# Altium schematic sheet Arak-DAC.SchDoc
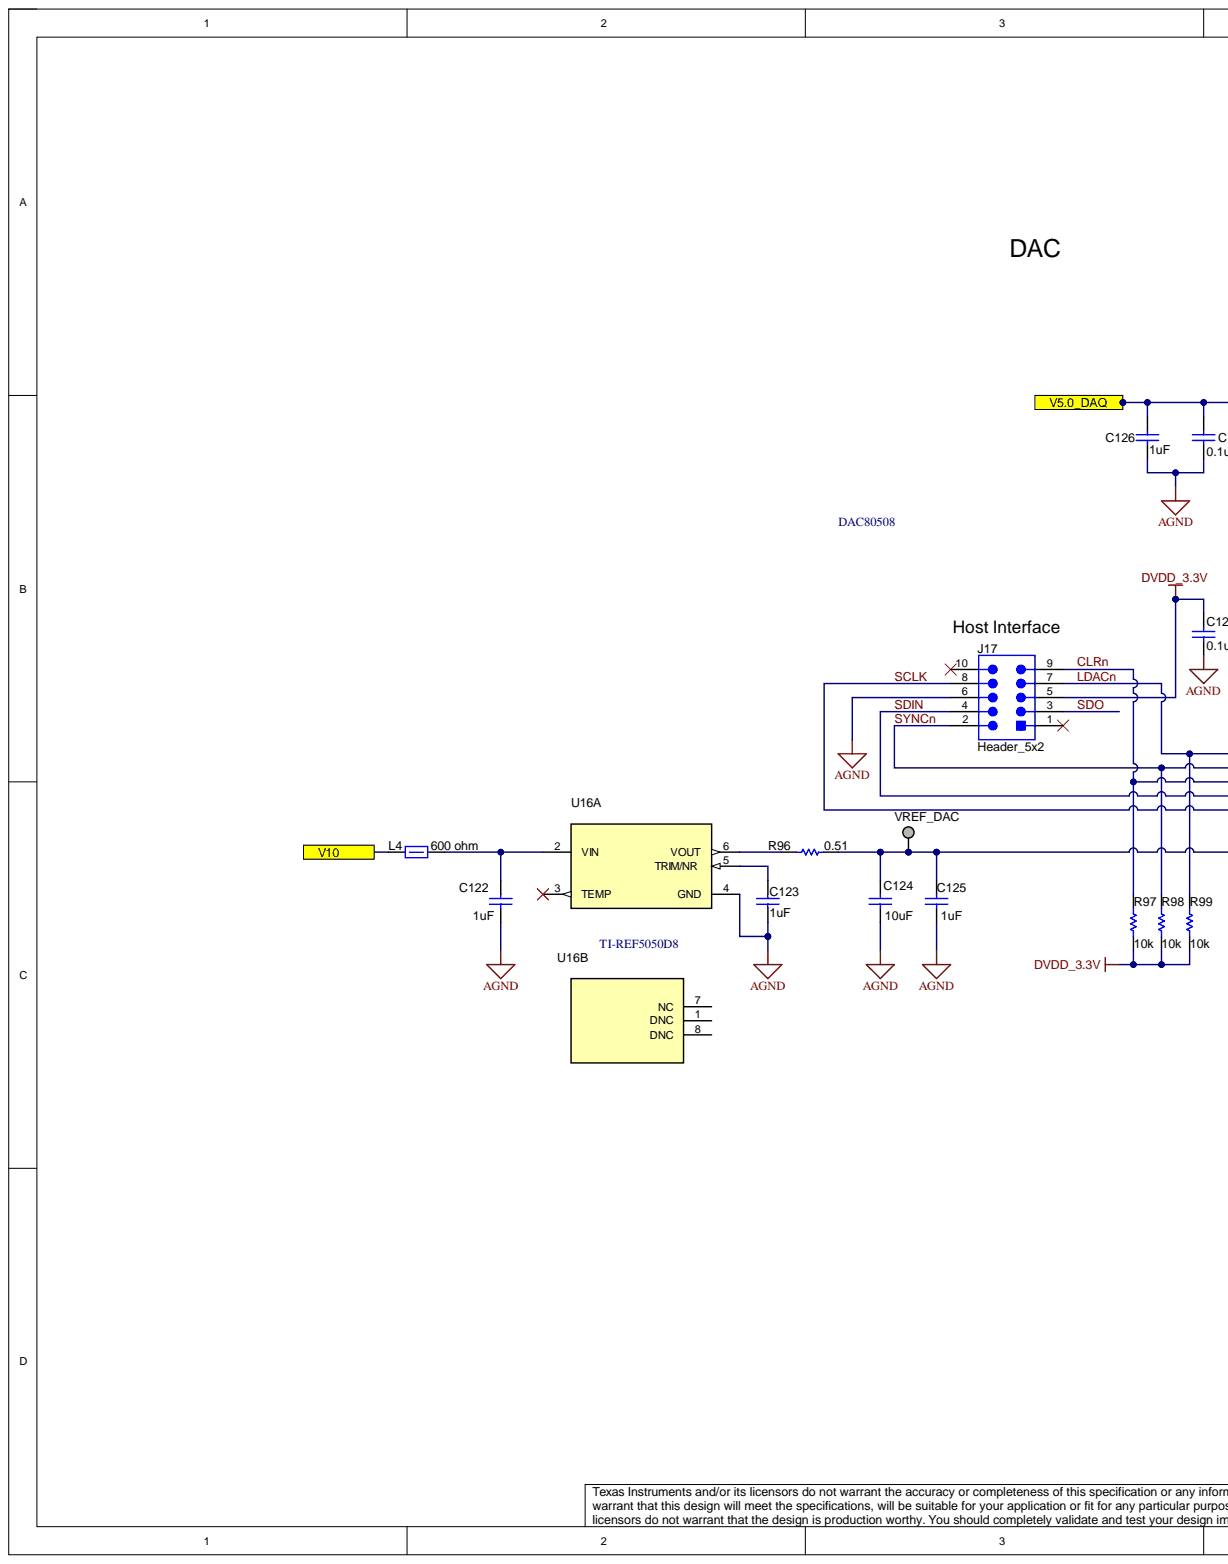
[diagram p0: Arak-DAC.SchDoc, left]
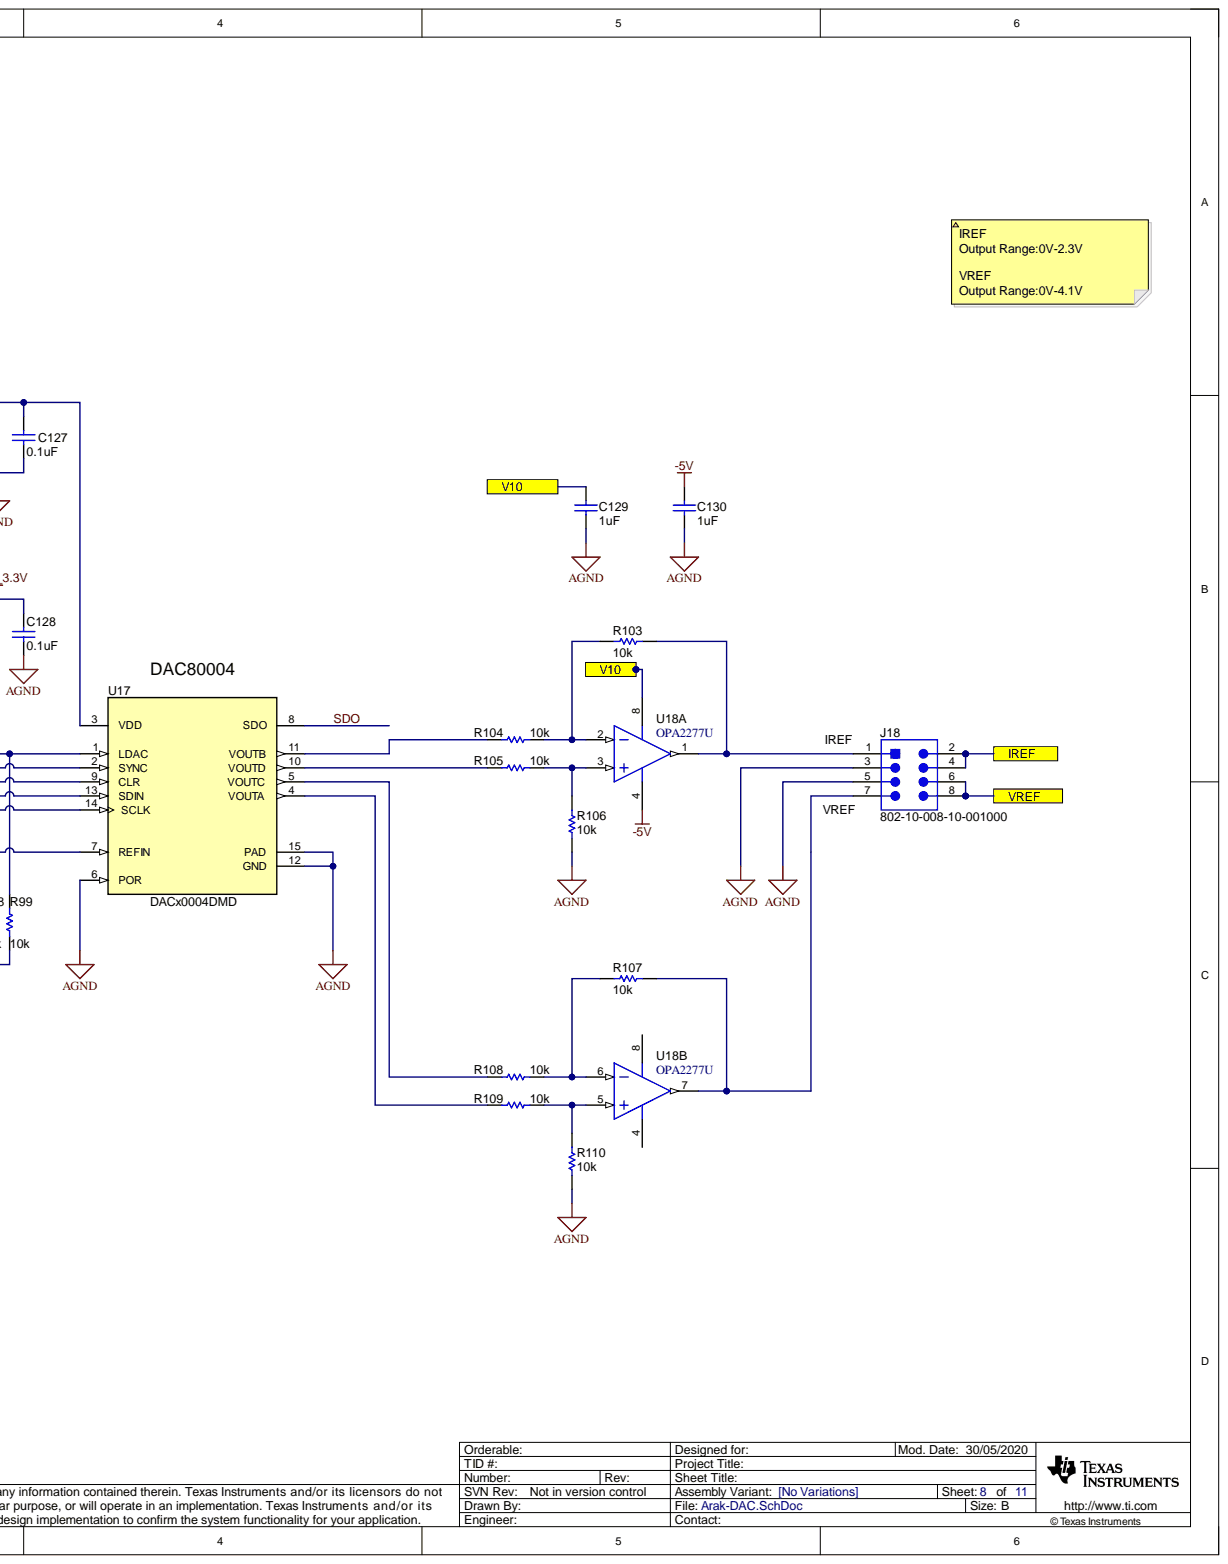
[diagram p1: Arak-DAC.SchDoc, right]

## Parts
C122  value 1uF  [1206]  (hidden comment: Capacitor)
C123  value 1uF  [1206]  (hidden comment: Capacitor)
C124  value 10uF  [0805_HV]  (hidden comment: Capacitor)
C125  value 1uF  [1206]  (hidden comment: Capacitor)
C126  value 1uF  [1206]  (hidden comment: Capacitor)
C127  value 0.1uF  [0603]  (hidden comment: Capacitor)
C128  value 0.1uF  [0603]  (hidden comment: Capacitor)
C129  value 1uF  [0603]  (hidden comment: Capacitor)
C130  value 1uF  [0603]  (hidden comment: Capacitor)
J17  Header_5x2  [Assman_AWHW-10G-0x02-T]
J18  802-10-008-10-001000  [Mill-Max_802-10-008-10-001000]
L4  value 600 ohm  [0603]  (hidden comment: Ferrite_Bead)
R96  value 0.51  [0603]  (hidden comment: Resistor)
R97  value 10k  Res_ohm: 10k  [0603]  (hidden comment: Resistor)
R98  value 10k  Res_ohm: 10k  [0603]  (hidden comment: Resistor)
R99  value 10k  Res_ohm: 10k  [0603]  (hidden comment: Resistor)
R103  value 10k  Res_ohm: 10k  [0603]  (hidden comment: Resistor)
R104  value 10k  Res_ohm: 10k  [0603]  (hidden comment: Resistor)
R105  value 10k  Res_ohm: 10k  [0603]  (hidden comment: Resistor)
R106  value 10k  Res_ohm: 10k  [0603]  (hidden comment: Resistor)
R107  value 10k  Res_ohm: 10k  [0603]  (hidden comment: Resistor)
R108  value 10k  Res_ohm: 10k  [0603]  (hidden comment: Resistor)
R109  value 10k  Res_ohm: 10k  [0603]  (hidden comment: Resistor)
R110  value 10k  Res_ohm: 10k  [0603]  (hidden comment: Resistor)
U16  TI-REF5050D8  [D0008A_N]  (parts A,B)
U17  DACx0004DMD  [DMD0014A]
U18  OPA2277U  [D0008A_N]  (parts A,B)
VREF_DAC  Pogo Pad  [PogoPad]  (value not drawn)  (no BOM)

## Ports
IREF, V5.0_DAQ, V10, VREF

## Nets
-5V: C130.1 U18.4
AGND: C122.2 C123.2 C124.2 C125.2 C126.2 C127.2 C128.2 C129.2 C130.2 J17.6 J18.3 J18.5 R106.1 R110.1 U16.4(GND) U17.6(POR) U17.12(GND) U17.15(PAD)
DVDD_3.3V: C128.1 J17.5 R97.1 R98.1 R99.1
CLRn: J17.9 R97.2 U17.9(CLR)
IREF: J18.2 J18.4 ; port IREF
LDACn: J17.7 R99.2 U17.1(LDAC)
SCLK: J17.8 U17.14(SCLK)
SDIN: J17.4 U17.13(SDIN)
SDO: J17.3 U17.8(SDO)
SYNCn: J17.2 R98.2 U17.2(SYNC)
V5.0_DAQ: C126.1 C127.1 U17.3(VDD) ; port V5.0_DAQ
V10: C129.1 L4.1 U18.8 ; port V10
VREF: J18.6 J18.8 ; port VREF
NetC122_1: C122.1 L4.2 U16.2(VIN)
NetC123_1: C123.1 U16.5(TRIM/NR)
NetC124_1: C124.1 C125.1 R96.2 U17.7(REFIN) VREF_DAC.1
NetJ18_1: J18.1 R103.1 U18.1
NetJ18_7: J18.7 R107.1 U18.7
NetR96_1: R96.1 U16.6(VOUT)
NetR103_2: R103.2 R104.1 U18.2
NetR104_2: R104.2 U17.11(VOUTB)
NetR105_1: R105.1 R106.2 U18.3
NetR105_2: R105.2 U17.10(VOUTD)
NetR107_2: R107.2 R108.1 U18.6
NetR108_2: R108.2 U17.5(VOUTC)
NetR109_1: R109.1 R110.2 U18.5
NetR109_2: R109.2 U17.4(VOUTA)

Not connected: J17 1 10; U16 1(DNC) 3(TEMP) 7(NC) 8(DNC)

## Library data of the parts
C122 C123 C125 C126 = CMP-0008509-2: CAP, CERM, 1 uF, 25 V, +/- 10%, X7R, 1206; Manufacturer: AVX; PartNumber: 12063C105KAT2A; AEC Category: Q200; AEC Qualified: N; Cap_F: 1µF; ESR_ohm: 0.013; SizeArea_mm2: 5.12; SizeAreaWB_mm2: 10.92; SizeX_mm: 3.2; SizeY_mm: 1.6; SizeZ_mm: 1.35; TaMax_C: 125°C; TaMin_C: -55°C; U/M: EA; Value1: 0.013 ohm; Value2: 25V; AEC Grade: N/A; Cap_Case: 1206; Component Kind: Standard; ComponentLink1Description: Datasheet link; ComponentLink1URL: http://datasheets.avx.com/X7RDielectric.pdf; CompType: Capacitor; LatestRevisionDate: 2017-03-09; Library Name: Altium Vault - TI; MountType: SMT; PackageReference: 1206; Polarized: N; Published: 2008-06-19; RoHS: Y; Supplier 1: Digi-Key; Supplier 2: Newark; Supplier 3: Mouser; Supplier Part Number 1: 478-1567-1-ND; Supplier Part Number 2: 96K4787; Supplier Part Number 3: 581-12063C105K; Technology: Ceramic; TempCo: X7R; Tolerance_%: 10%; ValueDisplayed: 1uF; VDC_V: 25V; Publisher: Texas Instruments
C124 = CMP-0008273-1: CAP, CERM, 10 uF, 35 V, +/- 10%, X6S, 0805; Manufacturer: MuRata; PartNumber: GRM21BC8YA106KE11L; AEC Category: Q200; AEC Qualified: N; Cap_Case: 0805; Cap_F: 10µF; SizeArea_mm2: 2.5; SizeAreaWB_mm2: 6.75; SizeX_mm: 2; SizeY_mm: 1.25; SizeZ_mm: 1.45; TaMax_C: 105°C; TaMin_C: -55°C; U/M: EA; Value1: Unknown; Value2: 35V; AEC Grade: N/A; Component Kind: Standard; ComponentLink1Description: Datasheet link; ComponentLink1URL: http://search.murata.co.jp/Ceramy/image/img/A01X/G101/ENG/GRM21BC8YA106KE11-01.pdf; CompType: Capacitor; LatestRevisionDate: 2017-03-09; Library Name: Altium Vault - TI; MountType: SMT; PackageReference: 0805; Polarized: N; Published: 2008-06-19; RoHS: Y; Supplier 1: Digi-Key; Supplier 2: Newark; Supplier 3: Mouser; Supplier Part Number 1: 490-10504-1-ND; Supplier Part Number 2: 96Y9269; Supplier Part Number 3: 81-GRM21BC8YA106KE1L; Technology: Ceramic; TempCo: X6S; Tolerance_%: 10%; ValueDisplayed: 10uF; VDC_V: 35V; Publisher: Texas Instruments
C127 C128 = CMP-0006857-4: CAP, CERM, 0.1 uF, 16 V, +/- 5%, X7R, 0603; Manufacturer: Kemet; PartNumber: C0603C104J4RACTU; AEC Category: Q200; Cap_F: 100nF; Polarized: N; SizeArea_mm2: 1.28; SizeAreaWB_mm2: 4.68; SizeX_mm: 1.6; SizeY_mm: 0.8; SizeZ_mm: 1; TaMax_C: 125°C; TaMin_C: -55°C; U/M: EA; Value1: Unknown; Value2: 16V; AEC Qualified: ?; Cap_Case: 0603; Component Kind: Standard; ComponentLink1Description: Datasheet link; ComponentLink1URL: http://www.kemet.com/kemet/web/homepage/kechome.nsf/vapubfiles/F3102_Y5V.pdf/$file/F3102_Y5V.pdf; CompType: Capacitor; LatestRevisionDate: 2017-03-09; Library Name: Altium Vault - TI; MountType: SMT; PackageReference: 0603; Published: 2008-06-19; RoHS: Y; Supplier 1: Digi-Key; Supplier 2: Mouser; Supplier 3: Farnell; Supplier Part Number 1: 399-1097-1-ND; Supplier Part Number 2: 80-C0603C104J4R; Supplier Part Number 3: 1650834; Technology: Ceramic; TempCo: X7R; Tolerance_%: 5%; ValueDisplayed: 0.1uF; VDC_V: 16V; Publisher: Texas Instruments
C129 C130 = CMP-0007067-4: CAP, CERM, 1 uF, 35 V, +/- 10%, X7R, AEC-Q200 Grade 1, 0603; Manufacturer: TDK; PartNumber: CGA3E1X7R1V105K080AC; AEC Category: Q200; AEC Grade: 1; AEC Qualified: Y; Cap_F: 1µF; ESR_ohm: 5.522m; IRMS_A: 2.21617A; Polarized: N; SizeArea_mm2: 1.28; SizeAreaWB_mm2: 4.68; SizeX_mm: 1.6; SizeY_mm: 0.8; TaMax_C: 125°C; TaMin_C: -55°C; U/M: EA; Value1: 0.005522 ohm; Value2: 35V; Cap_Case: 0603; Component Kind: Standard; ComponentLink1Description: Datasheet link; ComponentLink1URL: https://product.tdk.com/en/search/capacitor/ceramic/mlcc/info?part_no=CGA3E1X7R1V105K080AC; CompType: Capacitor; LatestRevisionDate: 2017-03-09; Library Name: Altium Vault - TI; MountType: SMT; PackageReference: 0603; Published: 2008-06-19; RoHS: Y; SizeZ_mm: 1; Supplier 1: Digi-Key; Supplier 2: Mouser; Supplier Part Number 1: 445-174215-1-ND; Supplier Part Number 2: 810-CGA3E1X7R1V105K080AC; Technology: Ceramic; TempCo: X7R; Tolerance_%: 10%; ValueDisplayed: 1uF; VDC_V: 35V; Publisher: Texas Instruments
J17 = CMP-0075161-1: Header(Shrouded), 2.54mm, 5x2, Gold, TH; Manufacturer: Assman WSW; PartNumber: AWHW-10G-0202-T; CNHeader: False; Gender: Male; Green_Lifecycle: Active; Green_REACh: Passed; Pitch_mm: 2.54; Row_Count: 2; Shrouded: Y; Color: Gray; Component Kind: Standard; ComponentLink1Description: Datasheet URL; ComponentLink1URL: http://www.assmann.us/specs/AWHW-xxG-0x02-T.pdf; CompType: Header; ContactFinish: Gold; CT: False; Green_EU RoHS: Passed; Green_EU RoHS-2: Incomplete; LatestRevisionDate: 2017-07-12; Library Name: Altium Vault - TI; MountType: TH; Orientation: Vertical; PackageReference: Header, 2.54mm, 5x2, TH; PinCount: 10; RoHS: Y; RowSpacing_mm: 2.54; Supplier 1: Digi-Key; Supplier Part Number 1: AWHW-10G-0202-T-ND; Publisher: Texas Instruments
J18 = CMP-0055096-1: Header, 2.54 mm, 4x2, Gold, TH; Manufacturer: Mill-Max; CNHeader: TRUE; CT: FALSE; Gender: Male; Pitch_mm: 2.54; Row_Count: 2; Shrouded: N; ClassName: Header; Color: Black; Component Kind: Standard; ComponentLink1Description: Datasheet URL; ComponentLink1URL: https://www.mill-max.com/assets/pdfs/metric/055M.PDF; CompType: Header; ContactFinish: Gold; LatestRevisionDate: 26/05/2017; Library Name: Altium Vault - TI; MountType: TH; Orientation: Vertical; PackageReference: Header, 2.54 mm, 4x2, TH; PartNumber: 802-10-008-10-001000; PinCount: 8; RoHS: Y; RowSpacing_mm: 2.54; Supplier 1: Digi-Key; Supplier Part Number 1: ED1309-08-ND; Publisher: Texas Instruments
L4 = CMP-0003197-2: Ferrite Bead, 600 ohm @ 100 MHz, 1 A, 0603; Manufacturer: Wurth Elektronik; PartNumber: 742792651; DCR_ohm: 2d; Imax_A: 1A; SizeArea_mm2: 1.28; SizeAreaWB_mm2: 4.68; SizeX_mm: 1.6; SizeY_mm: 0.8; SizeZ_mm: 1; Value1: 0.2 ohm; Ztyp@1GHz_Ohm: 257.548; ZTyp@100MHz_Ohm: 600; Component Kind: Standard; ComponentLink1Description: Datasheet link; ComponentLink1URL: http://katalog.we-online.de/pbs/datasheet/742792651.pdf; CompType: Ferrite Bead; LatestRevisionDate: 2017-03-09; Library Name: Altium Vault - TI; MountType: SMT; PackageReference: 0603; Published: 2014-04-30; RoHS: Y; Supplier 1: Digi-Key; Supplier 2: Newark; Supplier 3: Mouser; Supplier Part Number 1: 732-1593-1-ND; Supplier Part Number 2: 08P2439; Supplier Part Number 3: 710-742792651; Value2: 1A; ValueDisplayed: 600 ohm; Publisher: Texas Instruments
R96 = CMP-0022717-2: RES, 0.51, 1%, 0.1 W, AEC-Q200 Grade 1, 0603; Manufacturer: Panasonic; PartNumber: ERJ-3RQFR51V; AEC Category: Q200; AEC Grade: 1; AEC Qualified: Y; EIA_Code: E96; Power_W: 100mW; Res_ohm: 5.1d; SizeArea_mm2: 1.28; SizeX_mm: 1.6; SizeY_mm: 0.8; TempCo: 300 ppm/C; U/M: EA; Value2: 0.1W; Value_Code: R510; Component Kind: Standard; ComponentLink1Description: Datasheet link; ComponentLink1URL: http://industrial.panasonic.com/www-data/pdf/AOA0000/AOA0000CE3.pdf; CompType: Resistor; LatestRevisionDate: 2017-03-09; Library Name: Altium Vault - TI; MountType: SMT; PackageReference: 0603; Published: 2008-06-26; RoHS: Y; SizeZ_mm: 1; Supplier 1: Digi-Key; Supplier 2: Newark; Supplier 3: Mouser; Supplier Part Number 1: P.51AJCT-ND; Supplier Part Number 2: 51W9702; Supplier Part Number 3: 667-ERJ-3RQFR51V; Technology: Thick Film; Tolerance_%: 1%; Value1: 1%; ValueDisplayed: 0.51; Publisher: Texas Instruments
R97-R99 R103-R110 = CMP-0025701-4: RES, 10 k, 5%, 0.1 W, AEC-Q200 Grade 0, 0603; Manufacturer: Vishay-Dale; PartNumber: CRCW060310K0JNEA; AEC Category: Q200; AEC Grade: 0; AEC Qualified: Y; EIA_Code: E24; Power_W: 100mW; SizeArea_mm2: 1.28; SizeX_mm: 1.6; SizeY_mm: 0.8; SizeZ_mm: 1; TempCo: 200 ppm/C; Value2: 0.1W; Value_Code: 10k0; VDC_V: 75V; Component Kind: Standard; ComponentLink1Description: Datasheet link; ComponentLink1URL: http://www.vishay.com/docs/20035/dcrcwe3.pdf; CompType: Resistor; Library Name: Altium Vault - TI; MountType: SMT; PackageReference: 0603; RoHS: E; Supplier 1: Digi-Key; Supplier 2: Newark; Supplier 3: Mouser; Supplier Part Number 1: 541-10KGCT-ND; Supplier Part Number 2: 52K8066; Supplier Part Number 3: 71-CRCW0603J-10K-E3; Technology: Thick Film; Tolerance_%: 5%; Value1: 5%; ValueDisplayed: 10k
U16 = CMP-0032617-1: Low Noise, Very Low Drift, Precision Voltage Reference, -40 to 125 degC, 8-pin SOIC (D), Green (RoHS & no Sb/Br); Manufacturer: Texas Instruments; PartNumber: REF5050AID; Initial Accuracy @ 25/deg C(%): 0.05; Iout/Iz(Max)(mA): 10; Iq(Max)(uA): 1000; Rating: Catalog; SubFamily: Series Voltage Reference; Temp Coeff(Max)(ppm/ degree C): 3; VI(Max)(V): 18; VI(Min)(V): 5.2; VO(V): 5; Code_JEDEC: MS-012; Component Kind: Standard; ComponentLink1Description: Manufacturer URL; ComponentLink1URL: http://www.ti.com/; ComponentLink2Description: Datasheet; ComponentLink2URL: http://www.ti.com/general/docs/lit/getliterature.tsp?genericPartNumber=REF5050&fileType=pdf; ComponentLink3Description: Package Specification; ComponentLink3URL: http://www.ti.com/litv/pdf/msoi002j; DatasheetVersion: SBOS410E; Eco-Plan: Green (RoHS & no Sb/Br); Library Name: Altium Vault - TI; Mounting Technology: Surface Mount; PackageDescription: 8-Pin Plastic Small Outline, Body 4.9 x 3.9 mm, Pitch 1.27 mm; PackageReference: D0008A; PackageVersion: 4040047-3/M
U17 = CMP-0013342-2: QUAD 16-BIT, 1LSB INL, BUFFERED, VOLTAGE-OUTPUT DIGITAL-to-ANALOG CONVERTERS, DMD0014A (VSON-14); Alternate Manufacturer: Texas Instruments; PartNumber: DAC80004IDMD; CompType: IC; SizeArea_mm2: 12; SizeAreaWB_mm2: 20; SizeX_mm: 3; SizeY_mm: 4; SizeZ_mm: 1; U/M: EA; Component Kind: Standard; ComponentLink1Description: Datasheet Link; ComponentLink1URL: https://sps06.itg.ti.com/sites/KMS/crossdivteams/altium/docs/ComponentDatasheets/DAC80004.pdf; LatestRevisionDate: 2017-03-09; Library Name: Altium Vault - TI; Manufacturer: Texas Instruments; MountType: SMT; PackageDescription: VSON-14; PackageReference: DMD0014A; Publisher: Texas Instruments
U18 = CMP-0040655-1: High Precision Operational Amplifier, 4 to 36 V, -40 to 85 degC, 8-pin SOIC (D8), Green (RoHS & no Sb/Br); Manufacturer: Texas Instruments; CMRR(Min)(dB): 130; GBW(Typ)(MHz): 1; IIB(Max)(pA): 1000; Iq per channel(Max)(mA): 0.825; Number of Channels: 2; Offset Drift(Typ)(uV/C): 0.1; Packing: TUBE; Rating: Catalog; Slew Rate(Typ)(V/us): 0.8; Sub Family: High Supply Voltage Amplifier (>=30V), Low Noise Amp (<=10nV/rtHz), Low Offset Voltage Amplifier (<=500uV), Precision Amplifier; Total Supply Voltage(Max)(+5V is 5, +/-5V is 10): 36; Total Supply Voltage(Min)(+5V is 5, +/-5V is 10): 4; Vio (25C)(Max)(mV): 0.025; Code_JEDEC: MS-012; Component Kind: Standard; ComponentLink1Description: Manufacturer URL; ComponentLink1URL: http://www.ti.com/; ComponentLink2Description: Datasheet; ComponentLink2URL: http://www.ti.com/lit/ds/symlink/opa2277.pdf; ComponentLink3Description: Package Specification; ComponentLink3URL: http://www.ti.com/litv/pdf/msoi002j; DatasheetVersion: SBOS079A; Eco-Plan: Green (RoHS & no Sb/Br); Library Name: Altium Vault - TI; Mounting Technology: Surface Mount; PackageDescription: 8-Pin Plastic Small Outline, Body 4.9 x 3.9 mm, Pitch 1.27 mm; PackageReference: D0008A; PackageVersion: 4040047-3/M, 06/2011; PartNumber: OPA2277U
VREF_DAC = PogoPad: Pad for Pogo Pin Connector; SymbolCreator: codlsc; CompType: Pogo Pad; LatestRevisionDate: 2016-12-05; LatestRevisionNote: Added Alternate PartNumber and Alternate Manufacturer parameters to appropriate components.; Library Name: MiscParts.IntLib; PackageReference: N/A; SymbolVersion: 2010-09-27; Publisher: Texas Instruments
